# Altium schematic sheet 1. Power&Connectors.SchDoc("1. Power&Connectors")
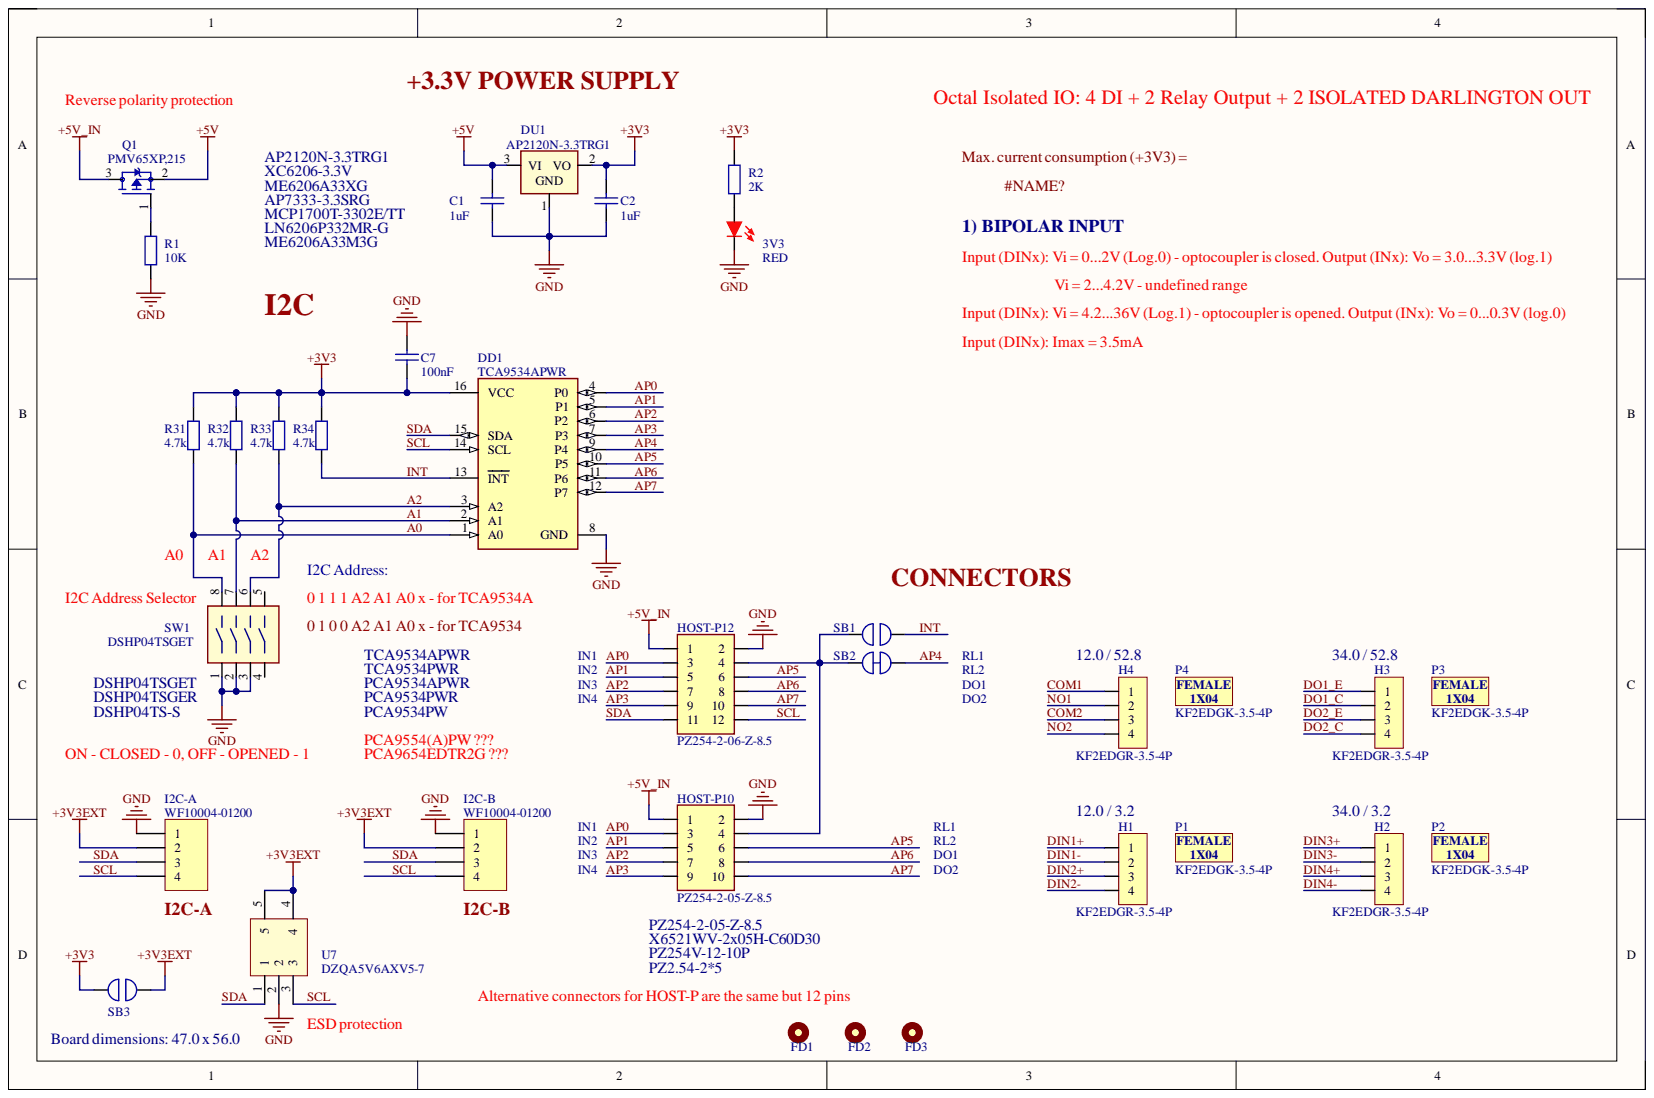
[diagram p0: 1. Power&Connectors.SchDoc]

## Parts
3V3  RED  [LED0603_RED]
C1  1uF  [CAPC1608X100N]
C2  1uF  [CAPC1608X100N]
C7  100nF  [CAPC1608X100N]
DD1  TCA9534APWR  [PW0016A TSSOP16]
DU1  AP2120N-3.3TRG1  [SOT95P240X110-3N]
FD1  FIDUCIAL_MARK  [FIDUCIAL_MARK_1MM]  (value not drawn)  (no BOM)
FD2  FIDUCIAL_MARK  [FIDUCIAL_MARK_1MM]  (value not drawn)  (no BOM)
FD3  FIDUCIAL_MARK  [FIDUCIAL_MARK_1MM]  (value not drawn)  (no BOM)
H1  KF2EDGR-3.5-4P  [CON_1X04_3.5MM_MALE_RA]
H2  KF2EDGR-3.5-4P  [CON_1X04_3.5MM_MALE_RA]
H3  KF2EDGR-3.5-4P  [CON_1X04_3.5MM_MALE_RA]
H4  KF2EDGR-3.5-4P  [CON_1X04_3.5MM_MALE_RA]
HOST-P10  PZ254-2-05-Z-8.5  [PLD-10]
HOST-P12  PZ254-2-06-Z-8.5  [PLD-12]
I2C-A  WF10004-01200  [SM04B-SRSS-TB(LF)(SN)]
I2C-B  WF10004-01200  [SM04B-SRSS-TB(LF)(SN)]
P1  KF2EDGK-3.5-4P  (mechanical)
P2  KF2EDGK-3.5-4P  (mechanical)
P3  KF2EDGK-3.5-4P  (mechanical)
P4  KF2EDGK-3.5-4P  (mechanical)
Q1  PMV65XP,215  [SOT95P230X110-3N]
R1  10K  [RESC-0603 (1608) - HD]
R2  2K  [R0402]
R31  4.7k  [RESC-0603 (1608) - HD]
R32  4.7k  [RESC-0603 (1608) - HD]
R33  4.7k  [RESC-0603 (1608) - HD]
R34  4.7k  [RESC-0603 (1608) - HD]
SB1  SOLDER_JUMPER_NO  [SOLDER_JUMPER_NO]  (value not drawn)
SB2  SOLDER_JUMPER_NC  [SOLDER_JUMPER_NC]  (value not drawn)
SB3  SOLDER_JUMPER_NO  [SOLDER_JUMPER_NO]  (value not drawn)
SW1  DSHP04TSGET  PartNumber2: DSHP04TSGER  [DIP-SW-4-1.27]
U7  DZQA5V6AXV5-7  [SOT50P160X60-5N]

## Nets
+3V3: C2.2 C7.1 DD1.16 DU1.2 R2.2 R31.1 R32.1 R33.1 R34.1 SB3.2
+3V3EXT: I2C-A.2 I2C-B.2 SB3.1 U7.4 U7.5
+5V: C1.2 DU1.3 Q1.2
+5V_IN: HOST-P10.1 HOST-P12.1 Q1.3
GND: 3V3.K C1.1 C2.1 C7.2 DD1.8 DU1.1 HOST-P10.2 HOST-P12.2 I2C-A.1 I2C-B.1 R1.1 SW1.1 SW1.2 SW1.3 U7.2
A0: DD1.1 R31.2 SW1.8
A1: DD1.2 R32.2 SW1.7
A2: DD1.3 R33.2 SW1.6
AP0: DD1.4 HOST-P10.3 HOST-P12.3
AP1: DD1.5 HOST-P10.5 HOST-P12.5
AP2: DD1.6 HOST-P10.7 HOST-P12.7
AP3: DD1.7 HOST-P10.9 HOST-P12.9
AP4: DD1.9 SB2.2
AP5: DD1.10 HOST-P10.6 HOST-P12.6
AP6: DD1.11 HOST-P10.8 HOST-P12.8
AP7: DD1.12 HOST-P10.10 HOST-P12.10
COM1: H4.1
COM2: H4.3
DIN1+: H1.1
DIN1-: H1.2
DIN2+: H1.3
DIN2-: H1.4
DIN3+: H2.1
DIN3-: H2.2
DIN4+: H2.3
DIN4-: H2.4
DO1_C: H3.2
DO1_E: H3.1
DO2_C: H3.4
DO2_E: H3.3
INT: DD1.13 R34.2 SB1.1
NO1: H4.2
NO2: H4.4
SCL: DD1.14 HOST-P12.12 I2C-A.4 I2C-B.4 U7.3
SDA: DD1.15 HOST-P12.11 I2C-A.3 I2C-B.3 U7.1
Net3V3_A: 3V3.A R2.1
NetHOST-P10_4: HOST-P10.4 HOST-P12.4 SB1.2 SB2.1
NetQ1_1: Q1.1 R1.2

Not connected: FD1 1; FD2 1; FD3 1; SW1 4 5

## Library data of the parts
3V3 = LED0603_RED: LED RED CLEAR CHIP SMD 0603; Manufacturer: HONGLITRONIC(Hongli Zhihui (HONGLITRONIC)); Manufacturer Part Number: HL-PST-1608U38FC; Dominant Wavelength: 631nm; Forward Current: 30mA; Forward Voltage: 2.4V; Lens Color: Colorless; Lens Style: Diffused,Colorless,Clear,Rectangular; Luminous Intensity: 54mcd; Number of Elements: 1; Power Dissipation: 75mW; Reverse Voltage: 5V; Test Current: 20mA; Viewing Angle: 130°; Wavelength: 639nm; Case Code (Imperial): 0603; Case Code (Metric): 1608; Case/Package: 0603; Category: LEDs; Color: Red; Component Kind: Standard; ComponentLink1Description: https://datasheet.ciiva.com/19482/1260381-19482354.pdf?src-supplier=Jameco; ComponentLink1URL: https://datasheet.ciiva.com/19482/1260381-19482354.pdf?src-supplier=Jameco; ComponentLink2Description: https://datasheet.ciiva.com/11774/0900766b80dc02a9-11774043.pdf?src-supplier=RSComponents; ComponentLink2URL: https://datasheet.ciiva.com/11774/0900766b80dc02a9-11774043.pdf?src-supplier=RSComponents; ComponentLink3Description: https://datasheet.ciiva.com/12403/100-ltst-c190krkt-59805-12403895.pdf?src-supplier=Mouser; ComponentLink3URL: https://datasheet.ciiva.com/12403/100-ltst-c190krkt-59805-12403895.pdf?src-supplier=Mouser; ComponentLink4Description: https://datasheet.ciiva.com/16427/ltst-c190krkt-16427674.pdf?src-supplier=Future+Electronics; ComponentLink4URL: https://datasheet.ciiva.com/16427/ltst-c190krkt-16427674.pdf?src-supplier=Future+Electronics; ComponentLink5Description: https://datasheet.ciiva.com/15117/getdatasheetpartid-57395-15117240.pdf?src-supplier=Verical; ComponentLink5URL: https://datasheet.ciiva.com/15117/getdatasheetpartid-57395-15117240.pdf?src-supplier=Verical; ComponentLink6Description: https://datasheet.ciiva.com/2109/s-110-ltst-c190krkt-2109992.pdf?src-supplier=Digi-Key; ComponentLink6URL: https://datasheet.ciiva.com/2109/s-110-ltst-c190krkt-2109992.pdf?src-supplier=Digi-Key; ComponentLink7Description: https://datasheet.ciiva.com/2109/s-110-ltst-c190krkt-2109991.pdf?src-supplier=Digi-Key; ComponentLink7URL: https://datasheet.ciiva.com/2109/s-110-ltst-c190krkt-2109991.pdf?src-supplier=Digi-Key; ComponentLink8Description: https://datasheet.ciiva.com/2109/s-110-ltst-c190krkt-2109993.pdf?src-supplier=Digi-Key; ComponentLink8URL: https://datasheet.ciiva.com/2109/s-110-ltst-c190krkt-2109993.pdf?src-supplier=Digi-Key; ComponentLink9Description: https://datasheet.ciiva.com/26937/getdatasheetpartid-631582-26937958.pdf?src-supplier=Verical; ComponentLink9URL: https://datasheet.ciiva.com/26937/getdatasheetpartid-631582-26937958.pdf?src-supplier=Verical; Depth: 0.8mm; Height: 0.8mm; Illumination Color: Red; Lead Free: Lead Free; Length: 1.6mm; Lens Transparency: Clear; Library Name: Schematic_Lib.SchLib; Max Operating Temperature: 85°C; Min Operating Temperature: -55°C; Mount: Surface Mount; Number of LEDs: 1; Number of Pins: 2; Package Quantity: 1; Packaging: Tape and Reel; Power Rating: 75mW; Pricing 1: 1=0.24000, 10=0.13200, 100=0.06110, 500=0.05640, 1000=0.04418, 2000=0.04324 (USD); Radiation Hardening: No; RoHS: Yes; RoHS Compliant: Yes; Stock 1: 843370; Supplier 1: LCSC; Supplier Part Number 1: C497928; Width: 0.8mm
C1 C2 = Cap: CAP CER 1UF 50V X5R 0603; Component Kind: Standard; LatestRevisionDate: 17-Jul-2002; LatestRevisionNote: Re-released for DXP Platform.; Library Name: Miscellaneous Devices.IntLib; PackageDescription: Radial Cap, Thru-Hole; 2 Leads; 0.3 in Pin Spacing; PackageReference: RAD-0.3; Published: 8-Jun-2000; Signal Integrity: Cap; Simulation: CAP; Value: 1uF; Publisher: Altium Limited
C7 = Cap: CAP CER 0.1UF 50V X7R 0603; Component Kind: Standard; LatestRevisionDate: 17-Jul-2002; LatestRevisionNote: Re-released for DXP Platform.; Library Name: Miscellaneous Devices.IntLib; PackageDescription: Radial Cap, Thru-Hole; 2 Leads; 0.3 in Pin Spacing; PackageReference: RAD-0.3; Published: 8-Jun-2000; Signal Integrity: Cap; Simulation: CAP; Value: 100nF; Publisher: Altium Limited
DD1 = TCA9534APWR: IC I/O EXPANDER I2C 8B 16TSSOP; Manufacturer: Texas Instruments; Frequency: 400kHz; Interface: I2C,SMBus; Max Junction Temp: 100°C; Max Supply Voltage: 5.5V; Min Supply Voltage: 1.65V; Nominal Supply Current: 5-22uA; Number Of I/Os: 8; Standoff Height: 0.05mm; Ambient Temperature Range High: +85°C; Ambient Temperature Range Low: -40°C; Automotive: No; Category: IC; Component Kind: Standard; ComponentLink2Description: Datasheet; ComponentLink2URL: http://www.ti.com/lit/ds/symlink/tca9534a.pdf; Device Class L1: Integrated Circuits (ICs); Device Class L2: Interface ICs; Device Class L3: I/O Expanders; Digikey Description: IC I/O EXPANDER I2C 8B 16TSSOP; Height: 1.2mm; Lead Free: Yes; Manufacturer Part Number: TCA9534APWR; Octopart: https://octopart.com/tca9534apwr-texas+instruments-50333529; Package: PW0016A; RoHS: TRUE; Temperature: -40°C to +85°C; Temperature Range High: +85°C; Temperature Range Low: -40°C; Library Name: IoTextra_Input_2-03.SCHLIB
DU1 = AP2120N-3.3TRG1: Low-drop voltage regulator 3.3V 0.3A SOT-23; Component Kind: Standard; Value: AP2120N-3.3TRG1; Library Name: IoTextra_Input_2-03.SCHLIB
FD1-FD3 = FIDUCIAL_MARK: Checked_By: Dodig; Drawn_By: Puskar; BL Part Number: N/A; DateModified: 17-06-2013; Description: FIDUCIAL_MARK; Library Name: Schematic_Lib.SchLib; Manufacturer: N/A; Manufacturer Part Number: N/A
H1-H4 = CON_1X04_3.5MM_MALE_RA: 300V 8A 4 Bend -40℃~+105℃ 1 3.5mm Green Board Edge/Receptacle-Close Push-Pull,P=3.5mm Pluggable System Terminal Block ROHS; Manufacturer: Cixi Kefa Elec; Component Kind: Standard; Library Name: Schematic_Lib.SchLib; Manufacturer Part Number: KF2EDGR-3.5-4P; Supplier 1: LCSC; Supplier Part Number 1: C441173
HOST-P10 = PLD-10: 2.54mm Header V/T-type, 2x05 Pin; Component Kind: Standard; Value: PZ254-2-05-Z-8.5; Library Name: IoTextra_Input_2-03.SCHLIB
HOST-P12 = PLD-12: 2.54mm Header V/T-type, 2x06 Pin; Component Kind: Standard; Value: PZ254-2-06-Z-8.5; Library Name: IoTextra_Input_2-03.SCHLIB
I2C-A = Header 4: Header, 4-Pin; Manufacturer: SHENZHEN ATOM TECH; Component Kind: Standard; Library Name: Miscellaneous Connectors.IntLib; Manufacturer Part Number: WF10004-01200; Signal Integrity: Connector; Supplier 1: LCSC; Supplier Part Number 1: C479990
I2C-B = Header 4: 1x4P 1 1mm Male pin 4 -25℃~+85℃ 500mA Surface Mount SMD,P=1mm Wire To Board / Wire To Wire Connector ROHS; Manufacturer: SHENZHEN ATOM TECH; Component Kind: Standard; Library Name: Miscellaneous Connectors.IntLib; Manufacturer Part Number: WF10004-01200; Signal Integrity: Connector; Supplier 1: LCSC; Supplier Part Number 1: C479990
P1-P4 = CON_1X04_3.5MM_FEMALE_RA: 250V 7A 4 1.5 1 16~28 3.5mm Green Plug P=3.5mm Pluggable System Terminal Block ROHS; Manufacturer: Cixi Kefa Elec; Library Name: Schematic_Lib.SchLib; Manufacturer Part Number: KF2EDGK-3.5-4P; Supplier 1: LCSC; Supplier Part Number 1: C440849
Q1 = PMV65XP,215: MOSFET P-CH 20V 2.8A TO236AB; Manufacturer: Nexperia USA; Component Kind: Standard; Library Name: Symbol.SchLib; Manufacturer Part Number: PMV65XP,215; Supplier 1: LCSC; Supplier Part Number 1: C75561
R1 = RC0603FR-0710KL: RES 10K OHM 1% 1/10W 0603; MFR: YAGEO; Solder: SMT; Component Kind: Standard; LCSC #: C98220; MPN: RC0603FR-0710KL; Value: 10K; Library Name: Bokra.SCHLIB
R2 = 0402WGF2001TCE: -55℃~+155℃ 2kΩ 50V 62.5mW Thick Film Resistor ±1% ±100ppm/℃ 0402 Chip Resistor - Surface Mount ROHS; Component Kind: Standard; LCSC #: C4109; MPN: 0402WGF2001TCE; Value: 2K; Library Name: Bokra.SCHLIB
R31-R34 = RC0603FR-074K7L: RES 4.7K OHM 1% 1/10W 0603; MFR: Yageo; Category: RESISTOR; Component Kind: Standard; LCSC #: C99782; MPN: RC0603FR-074K7L; Solder: RES-0603; URL: https://www.digikey.com/en/products/detail/yageo/RC0603FR-074K7L/727212; Value: 4.7k; Library Name: Bokra.SCHLIB
SB1 SB3 = SOLDER_JUMPER_NO: Solder Jumper; Component Kind: Net Tie; Library Name: Bokra.SCHLIB
SB2 = SOLDER_JUMPER_NC: Solder Jumper; Component Kind: Net Tie; Library Name: Bokra.SCHLIB
SW1 = DIP-SW-4-1.27: DIP switch 4 pos pitch 1.27mm SMT; Component Kind: Standard; PartNumber1: DSHP04TSGET; PartNumber3: DSHP04TS-S; Value: DSHP04TSGET; Library Name: IoTextra_Input_2-03.SCHLIB
U7 = NZQA5V6XV5T1G: 5.3V 13V 4 3V SOT-553 ESD Protection Devices ROHS; Manufacturer: Diodes Incorporated; Component Kind: Standard; Manufacturer Part Number: DZQA5V6AXV5-7; Supplier 1: LCSC; Supplier Part Number 1: C1973056; Library Name: IoTbase B+ 3-01.SCHLIB
